# Altium schematic sheet MCU_32_1.SchDoc
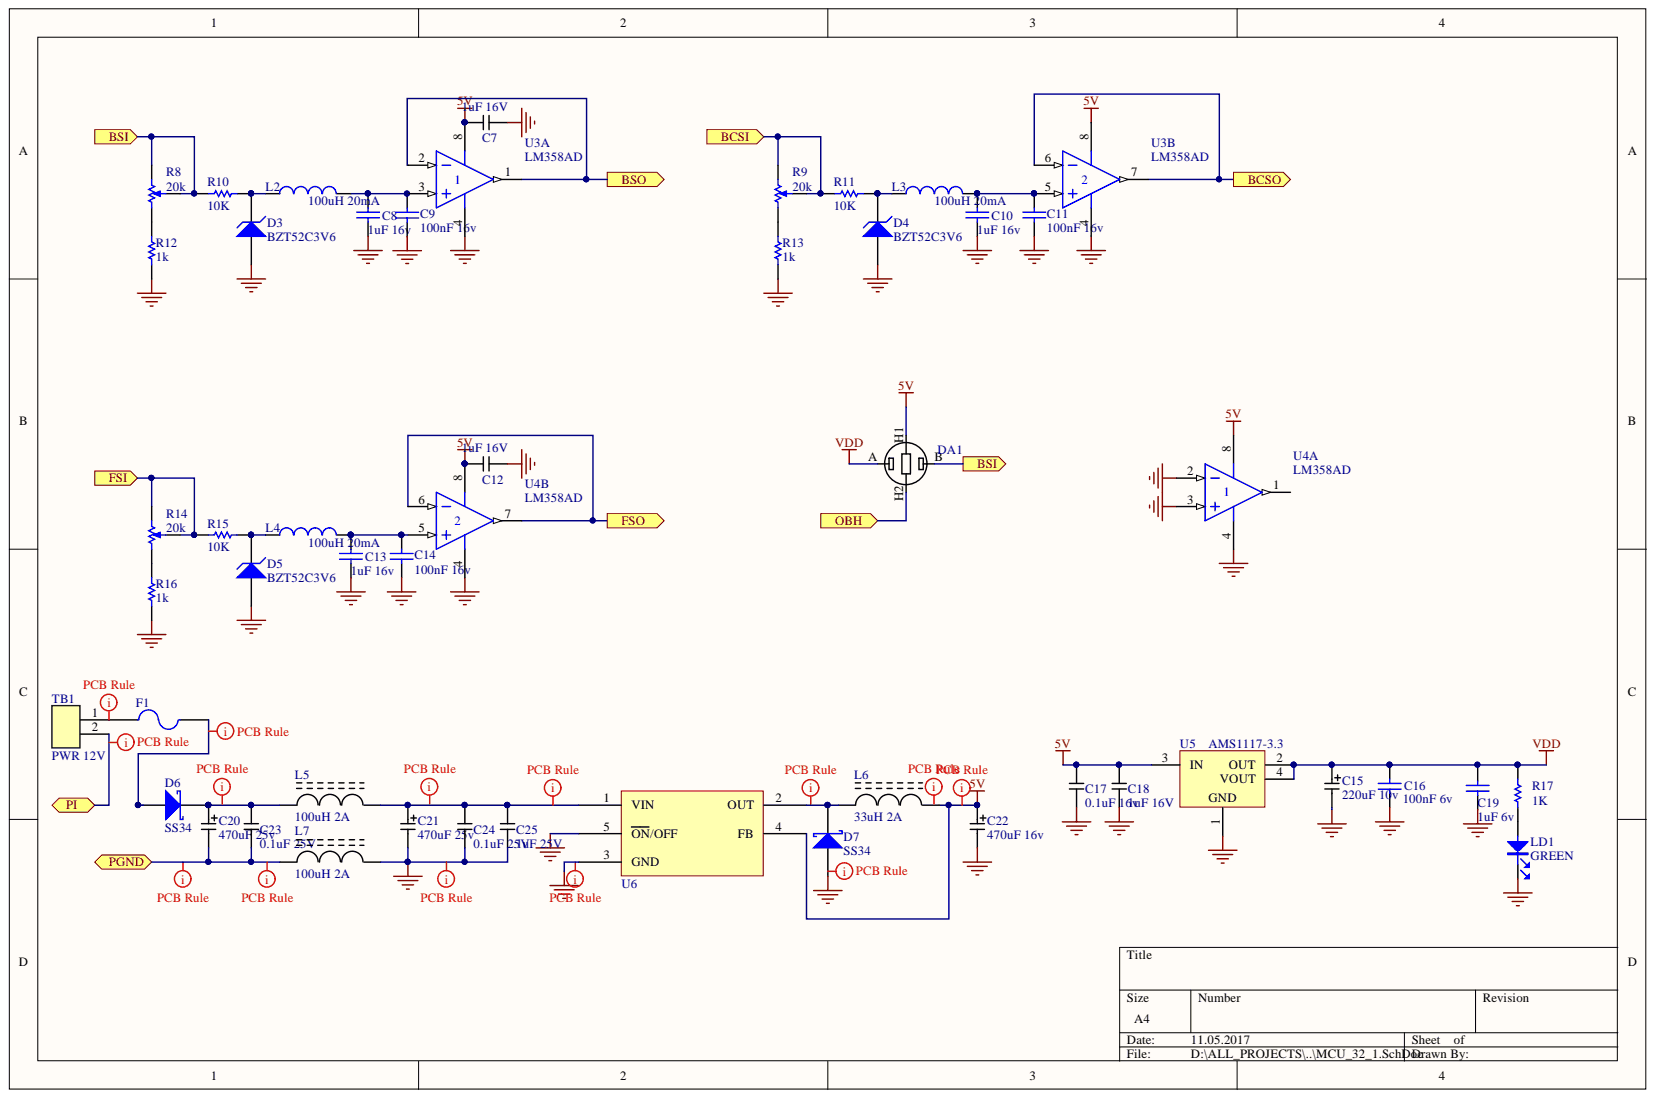
[diagram p0: MCU_32_1.SchDoc]

## Parts
C7  1uF 16V  [C1206]
C8  1uF 16v  [0603C]
C9  100nF 16v  [0603C]
C10  1uF 16v  [0603C]
C11  100nF 16v  [0603C]
C12  1uF 16V  [C1206]
C13  1uF 16v  [0603C]
C14  100nF 16v  [0603C]
C15  220uF 10v  [SMD D]
C16  100nF 6v  value 100nF  [0603C]
C17  0.1uF 16v  [C1206]
C18  1uF 16V  [C1206]
C19  1uF 6v  [0603C]
C20  470uF 25v  [C_EL_10]
C21  470uF 25v  [C_EL_10]
C22  470uF 16v  [C_EL_8]
C23  0.1uF 25V  [C1206]
C24  0.1uF 25V  [C1206]
C25  1uF 25V  [C1206]
D3  BZT52C3V6  [SOD-123]
D4  BZT52C3V6  [SOD-123]
D5  BZT52C3V6  [SOD-123]
D6  SS34  [SMB]
D7  SS34  [SMB]
DA1  Gas sensor MQ4  [MQ1]  (value not drawn)
F1  Fuse 2  [1812]  (value not drawn)
L2  100uH 20mA  value 100uH  [0603L]
L3  100uH 20mA  value 100uH  [0603L]
L4  100uH 20mA  value 100uH  [0603L]
L5  100uH 2A  [NR60]
L6  33uH 2A  [NR60]
L7  100uH 2A  [NR60]
LD1  GREEN  [0603D_R]
R8  20k  [POT4MM-2]
R9  20k  [POT4MM-2]
R10  10K  [0603R]
R11  10K  [0603R]
R12  1k  [RES 1206/3216]
R13  1k  [RES 1206/3216]
R14  20k  [POT4MM-2]
R15  10K  [0603R]
R16  1k  [RES 1206/3216]
R17  1K  [0603R]
TB1  PWR 12V  [terminal block2p]
U3  LM358AD  [SO-8]  (parts A,B)
U4  LM358AD  [SO-8]  (parts A,B)
U5  AMS1117-3.3  [369A-13]
U6  DC/DC reg LM2596S  [TO-263]  (value not drawn)

## Ports
BCSI, BCSO, BSI, BSO, FSI, FSO, OBH, PGND, PI

## Nets
5V: C7.1 C12.1 C17.1 C18.1 C22.1 DA1.H1 L6.2 U3.8 U4.8 U5.3(IN) U6.4(FB)
GND: C7.2 C8.2 C9.2 C10.2 C11.2 C12.2 C13.2 C14.2 C15.2 C16.1 C17.2 C18.2 C19.2 C21.2 C22.2 C24.2 C25.2 D3.1 D4.1 D5.1 D7.1 L7.2 LD1.2 R12.2 R13.2 R16.2 U3.4 U4.2 U4.3 U4.4 U5.1 U6.3(GND) U6.5(ON/OFF)
VDD: C15.1 C16.2 C19.1 DA1.A R17.2 U5.2(OUT) U5.4(VOUT)
BCSI: R9.CW R9.W R11.2 ; port BCSI
BCSO: U3.6 U3.7 ; port BCSO
BSI: DA1.B R8.CW R8.W R10.2 ; port BSI
BSO: U3.1 U3.2 ; port BSO
FSI: R14.CW R14.W R15.2 ; port FSI
FSO: U4.6 U4.7 ; port FSO
OBH: DA1.H2 ; port OBH
PGND: C20.2 C23.2 L7.1 ; port PGND
PI: TB1.2 ; port PI
NetC8_1: C8.1 C9.1 L2.2 U3.3
NetC10_1: C10.1 C11.1 L3.2 U3.5
NetC13_1: C13.1 C14.1 L4.2 U4.5
NetC20_1: C20.1 C23.1 D6.2 L5.1
NetC21_1: C21.1 C24.1 C25.1 L5.2 U6.1(VIN)
NetD3_2: D3.2 L2.1 R10.1
NetD4_2: D4.2 L3.1 R11.1
NetD5_2: D5.2 L4.1 R15.1
NetD6_1: D6.1 F1.2
NetD7_2: D7.2 L6.1 U6.2(OUT)
NetF1_1: F1.1 TB1.1
NetLD1_1: LD1.1 R17.1
NetR8_CCW: R8.CCW R12.1
NetR9_CCW: R9.CCW R13.1
NetR14_CCW: R14.CCW R16.1
N00054:
N00055:
N00056:
N00057:

Not connected: U4 1

## Library data of the parts
C7 C12 C18 = C: Capacitor 1uF 16V; Component Kind: Standard; Library Name: Passive.SchLib
C8 C10 C13 C19 = CAP SMD 0603  X5R 1µF 25V 10%: 0603  X5R 1µF; Rated voltage: 25V; Tolerance: 10%; Component Kind: Standard; Library Name: MB963_1.SCHLIB; Order code Farnell: 1288202RL; PCB3D: 0603_0_0000_R; Signal Integrity: Capacitor; Simulation: Capacitor; Technology: X5R; Temperature Max: +125°C; Temperature Min: -55°C; Value: 1µF
C9 C11 C14 = CAP: Capacitor; Value: 100pF; Component Kind: Standard; Library Name: Miscellaneous Devices.IntLib; Published: 8-Jun-2000; Revision: July-2002: Re-released for DXP Platform.; Signal Integrity: Cap; Simulation: CAP; Publisher: Altium Limited
C15 C20-C22 = CP: Capacitor polarized; Component Kind: Standard; Library Name: Passive.SchLib
C16 = Capacitor_ST: Capacitor 0402 / 0603 / 0805; Component Kind: Standard; Library Name: MCD_TOOLS.SchLib; Revision: July-2002: Re-released for DXP Platform.; Signal Integrity: Cap; Simulation: CAP
C17 = C: Capacitor 0.1uF 12V; Component Kind: Standard; Library Name: Passive.SchLib
C23 C24 = C: Capacitor 0.1uF 25V; Component Kind: Standard; Library Name: Passive.SchLib
C25 = C: Capacitor 1uF 25V; Component Kind: Standard; Library Name: Passive.SchLib
D3-D5 = BZT52C3V6: Surface Mount Zener Diode; Component Kind: Standard; ComponentLink1Description: Manufacturer Link; ComponentLink1URL: http://www.vishay.com/; DatasheetDocument: REV. L-2; LatestRevisionDate: 17-Jul-2002; LatestRevisionNote: Re-released for DXP Platform.; Library Name: Vishay Lite-On Discrete Diode.IntLib; PackageReference: SOD-123; Published: 8-Jun-2000; Signal Integrity: BZT52C3V6; Simulation: BZT52C3V6; Publisher: Altium Limited
D6 D7 = D Schottky: Schottky Diode 40V 3A; Code_JEDEC: DO-214-AA; Component Kind: Standard; LatestRevisionDate: 17-Jul-2002; LatestRevisionNote: Re-released for DXP Platform.; Library Name: Miscellaneous Devices.SchLib; PackageDescription: DO-214-AA/SMB; 2 C-Bend Leads; Body 5.3 x 3.6 mm, inc. leads (LxW); PackageReference: SMB; Published: 8-Jun-2000; Signal Integrity: D Schottky; Simulation: SKYDIODE; Publisher: Altium Limited
DA1 = MQ1: Component Kind: Standard; Library Name: MEMS.SchLib
F1 = Fuse 2: Fuse; Component Kind: Standard; LatestRevisionDate: 17-Jul-2002; LatestRevisionNote: Re-released for DXP Platform.; Library Name: Miscellaneous Devices.SchLib; PackageDescription: Thru-Hole; 2 Leads; PackageReference: PIN-W2/E2.8; Published: 8-Jun-2000; Simulation: FUSE; Publisher: Altium Limited
L2-L4 = Inductor: Component Kind: Standard; Description: Inductor; Library Name: Miscellaneous Devices.IntLib; Published: 8-Jun-2000; Revision: July-2002: Re-released for DXP Platform.; Publisher: Altium Limited
L5 L7 = L: Inductor 100uH 2A; Component Kind: Standard; Library Name: Passive.SchLib
L6 = L: Inductor 33uH 2A; Component Kind: Standard; Library Name: Passive.SchLib
LD1 = PHOTO: Component Kind: Standard; Library Name: MB963_1.SCHLIB
R8 R9 R14 = RPot SM: Square Trimming Potentiometer; Component Kind: Standard; ComponentLink1Description: Manufacturer Link; ComponentLink1URL: http://bourns.com/2/search.html; DatasheetVersion: Oct-2003; Library Name: Miscellaneous Devices.SchLib; PackageDescription: Square Trimming Potentiometer; PackageReference: POT4MM-2; Published: 17-Dec-2003; Publisher: Altium Limited
R10 R11 R15 = Res1: Resistor; Value: 1K; Component Kind: Standard; Library Name: Miscellaneous Devices.IntLib; Published: 8-Jun-2000; Revision: July-2002: Re-released for DXP Platform.; Signal Integrity: Res; Simulation: RESISTOR; Publisher: Altium Limited
R12 R13 R16 = Resistor1: Resistor; Component Kind: Standard; Library Name: PassiveSMT.schlib; Value: Value
R17 = Resistor_ST: Resistor 0402 / 0603 / 0805; Value: 10K; Component Kind: Standard; Library Name: MCD_TOOLS.SchLib
TB1 = Terminal block 2p: Terminal block 2p 2.54mm; Component Kind: Standard; Library Name: PCB_Project1.SCHLIB; Value: Value
U3 U4 = LM358AD: Dual Operational Amplifier; Code_IPC: SOIC127P600-8; Component Kind: Standard; ComponentLink1Description: Manufacturer Link; ComponentLink1URL: http://www.ti.com/; ComponentLink2Description: Datasheet; ComponentLink2URL: http://www-s.ti.com/sc/ds/lm358.pdf; DatasheetVersion: Aug-1988; LatestRevisionDate: 13-Apr-2006; LatestRevisionNote: IPC-7351 Footprint Added.; Library Name: TI Operational Amplifier.IntLib; PackageDescription: 8-Pin Small Outline Integrated Circuit 1.27 mm Pitch; PackageReference: D008; PackageVersion: Jan-1998; PCB3D: D008; Published: 15-Apr-2002; Publisher: Altium Limited
U5 = LT1117CM-5: 1 A Low Dropout Positive Regulator, 2.45 to 15 V Vin, 5 V Vout, 3-pin DPAK (M-3), 0 to 125 degC; Manufacturer: Linear Technology; Discrete Pass Element: no; Dropout Voltage(V): 1.1; Iout(mA): 800; Isupply(mA): 5; Number of Outputs: 1; Output Voltage: 5 V; PSRR(dB): 75; Vin Max(V): 15; Vin Min(V): 2.45; Component Kind: Standard; ComponentLink1Description: Manufacturer URL; ComponentLink1URL: http://www.linear.com/; ComponentLink2Description: Datasheet; ComponentLink2URL: http://cds.linear.com/docs/en/datasheet/1117fd.pdf; ComponentLink3Description: Package Specification; ComponentLink3URL: http://cds.linear.com/docs/en/packaging/05081460_F_M.pdf; DatasheetVersion: Rev. D; Library Name: Linear Technology Power Management LDO Linear Regulators.IntLib; Mounting Technology: Surface Mount; PackageDescription: 3-Pin Plastic DD Pak, Body 10.2235 x 8.89 mm, Pitch 2.54 mm; PackageReference: M-3; PackageVersion: Rev. F, 05/2012; PartNumber: LT1117CM-5; RoHS: FALSE; Supplier 1: Digi-Key; Supplier 2: Newark; Supplier Part Number 1: LT1117CM-5-ND; Supplier Part Number 2: 57M1785
U6 = TI LM2596: Component Kind: Standard; Library Name: Voltage Regulators Switchmode.SchLib
